# Altium schematic sheet Display_7Seg.SchDoc(Display_7Seg)
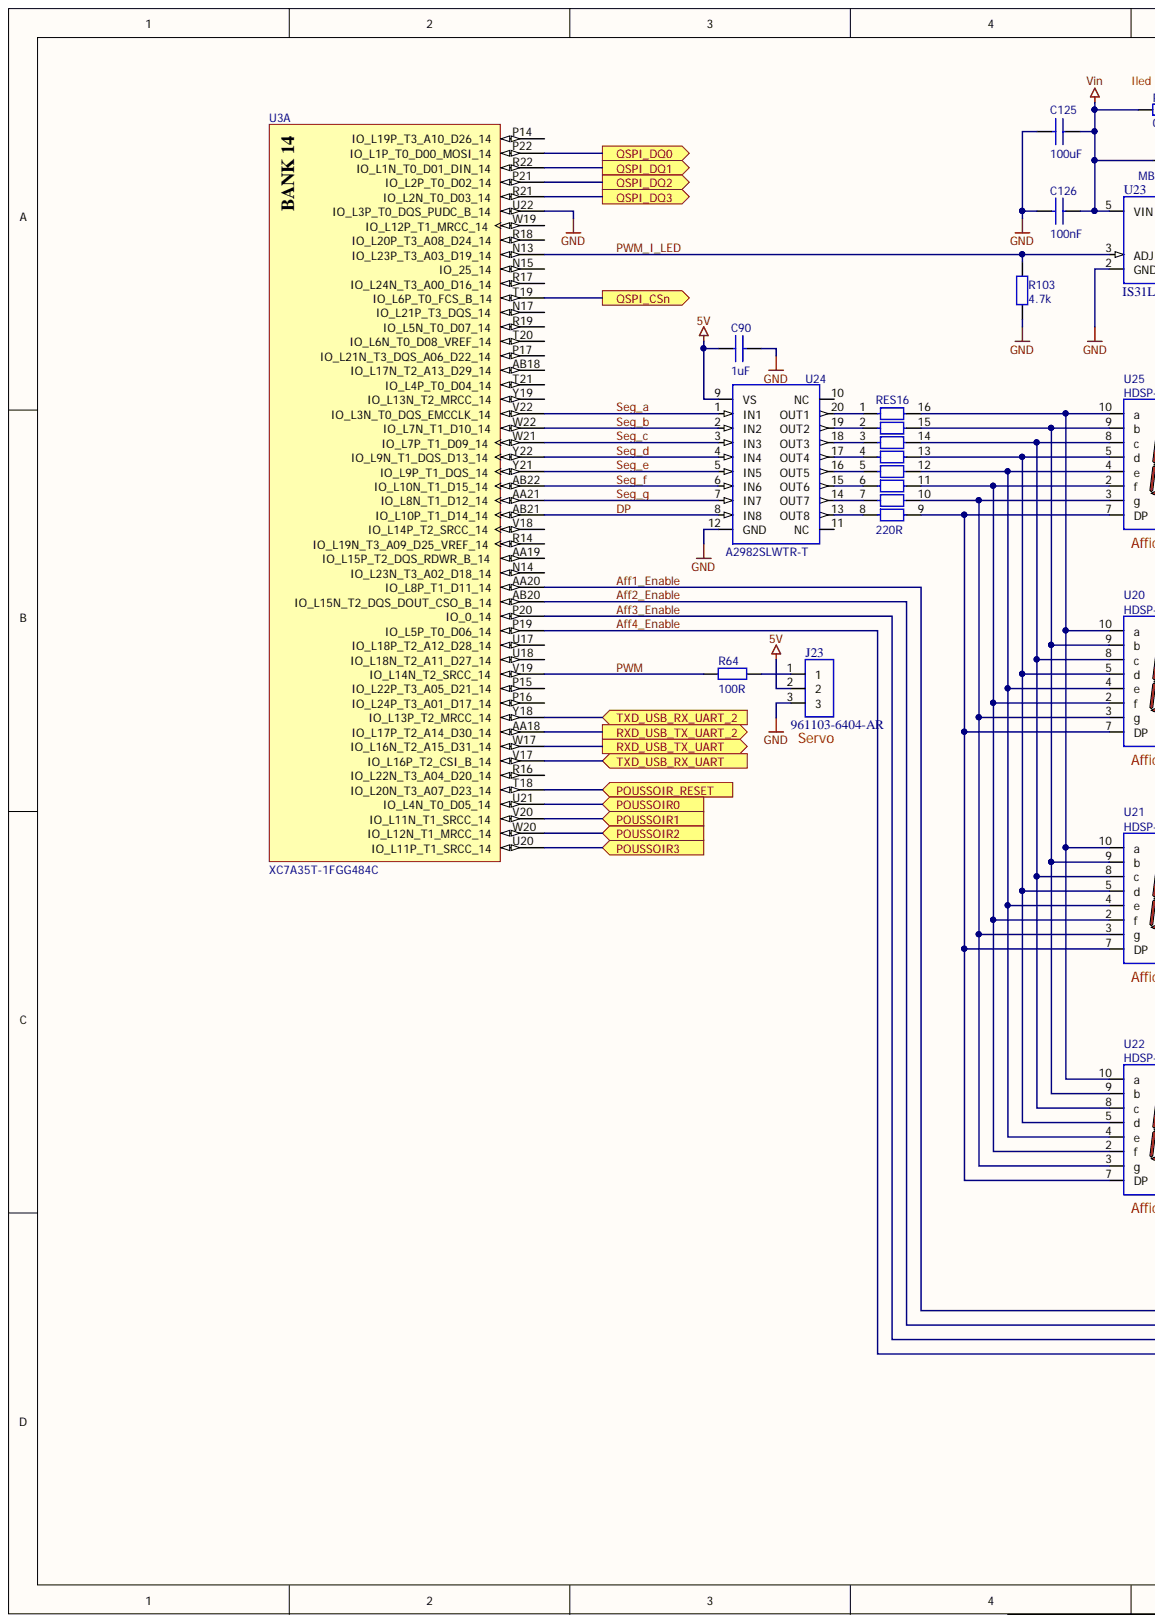
[diagram p0: Display_7Seg.SchDoc, left]
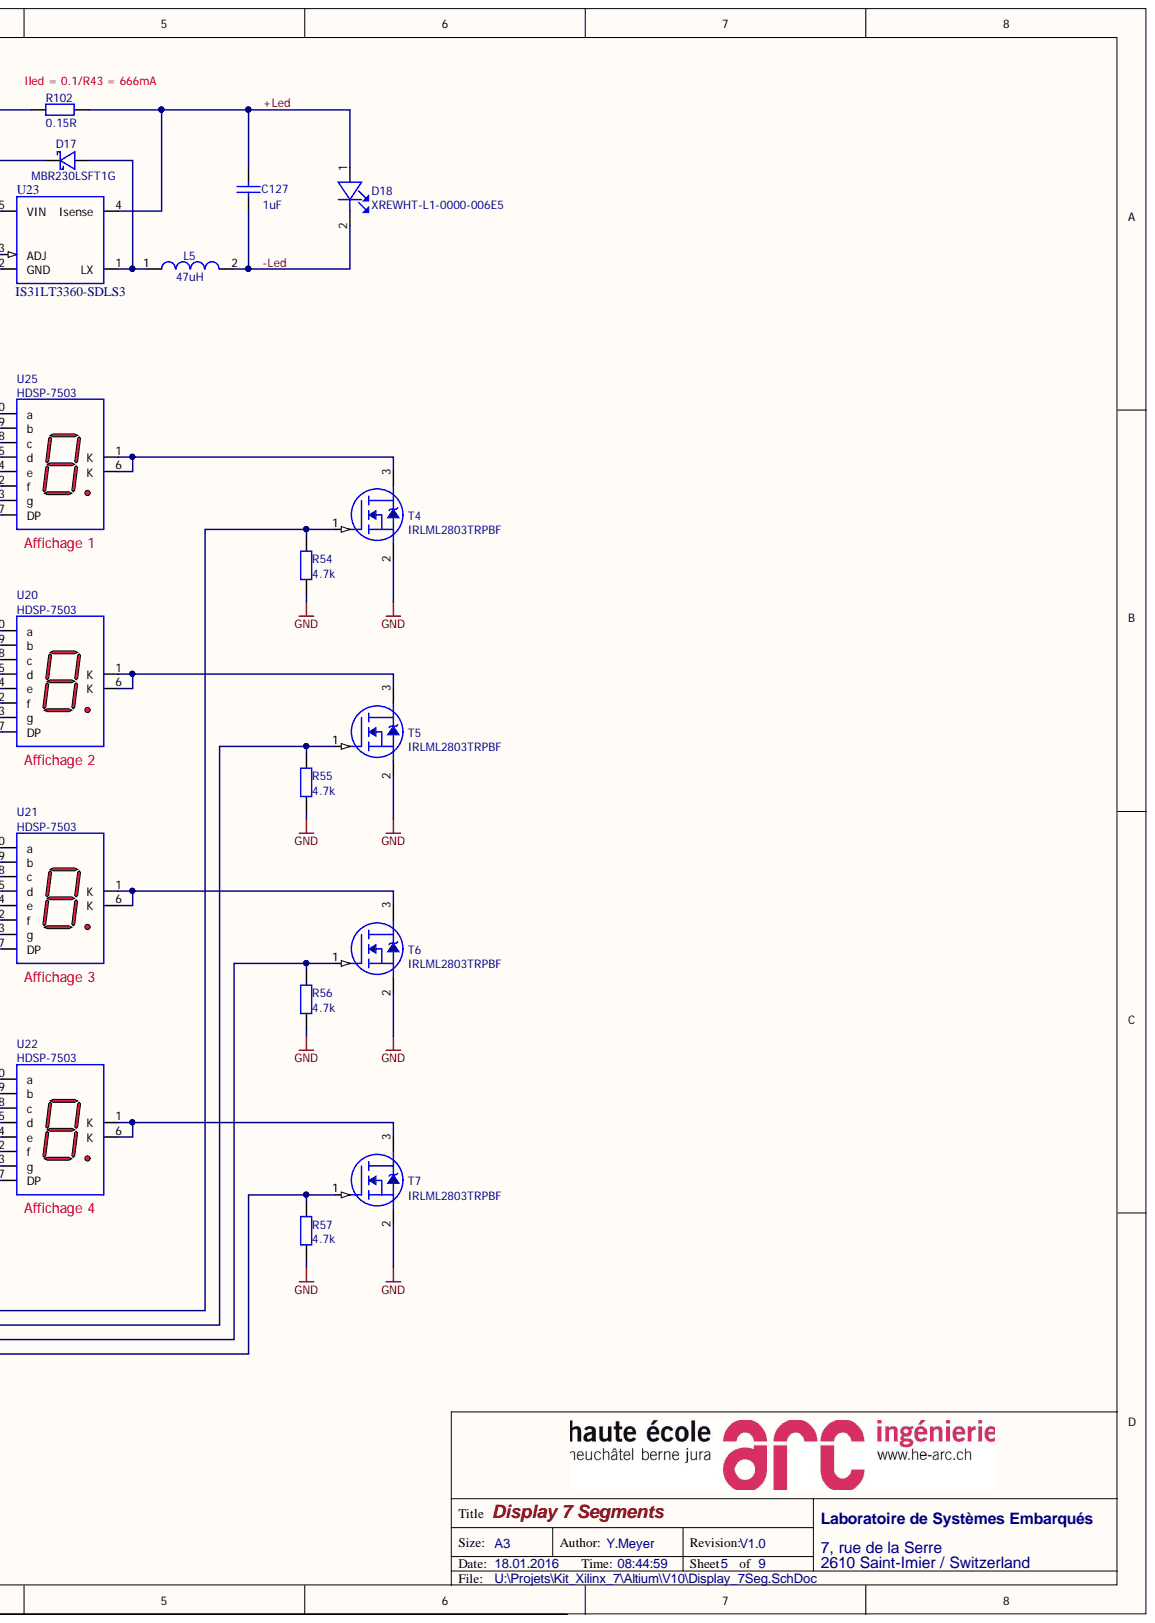
[diagram p1: Display_7Seg.SchDoc, right]

## Parts
C90  value 1uF  [060301]  (hidden comment: C0603C105K4RACTU)
C125  value 100uF  [121001]  (hidden comment: GRM32ER60J107ME20L)
C126  value 100nF  [060301]  (hidden comment: C0603C104Z3VAC7867)
C127  value 1uF  [060301]  (hidden comment: C0603C105K4RACTU)
D17  MBR230LSFT1G  [SOD123LF]
D18  XREWHT-L1-0000-006E5  [D008]
J23  961103-6404-AR  [J103A01]
L5  value 47uH  [SRN6045]  (hidden comment: SRN6045-470M)
R54  value 4.7k  [060301]  (hidden comment: ERJ-3EKF4701V)
R55  value 4.7k  [060301]  (hidden comment: ERJ-3EKF4701V)
R56  value 4.7k  [060301]  (hidden comment: ERJ-3EKF4701V)
R57  value 4.7k  [060301]  (hidden comment: ERJ-3EKF4701V)
R64  value 100R  [060301]  (hidden comment: RC0603FR-07100RL)
R102  value 0.15R  [120601]  (hidden comment: RL1206FR-7W0R15L)
R103  value 4.7k  [060301]  (hidden comment: ERJ-3EKF4701V)
RES16  value 220R  [SOP1601]  (hidden comment: 767163221GP)
T4  IRLML2803TRPBF  [SOT2301]
T5  IRLML2803TRPBF  [SOT2301]
T6  IRLML2803TRPBF  [SOT2301]
T7  IRLML2803TRPBF  [SOT2301]
U3  XC7A35T-1FGG484C  [FGG484]  (part A)
U20  HDSP-7503  [HDSP-7503]
U21  HDSP-7503  [HDSP-7503]
U22  HDSP-7503  [HDSP-7503]
U23  IS31LT3360-SDLS3  [SOT89-5]
U24  A2982SLWTR-T  [SOL2001]
U25  HDSP-7503  [HDSP-7503]

## Ports
POUSSOIR0, POUSSOIR1, POUSSOIR2, POUSSOIR3, POUSSOIR_RESET, QSPI_CSN, QSPI_DQ0, QSPI_DQ1, QSPI_DQ2, QSPI_DQ3, RXD_USB_TX_UART, RXD_USB_TX_UART_2, TXD_USB_RX_UART, TXD_USB_RX_UART_2

## Nets
5V: C90.1 J23.2 U24.9(VS)
GND: C90.2 C125.2 C126.2 J23.3 R54.1 R55.1 R56.1 R57.1 R103.2 T4.2 T5.2 T6.2 T7.2 U3.U22(IO_L3P_T0_DQS_PUDC_B_14) U23.2(GND) U24.12(GND)
QSPI_CSN: U3.T19(IO_L6P_T0_FCS_B_14) ; port QSPI_CSN
VIN: C125.1 C126.1 D17.1 R102.2 U23.5(VIN)
+LED: C127.1 D18.1 R102.1 U23.4(Isense)
-LED: C127.2 D18.2 L5.2
AFF1_ENABLE: R54.2 T4.1 U3.AA20(IO_L8P_T1_D11_14)
AFF2_ENABLE: R55.2 T5.1 U3.AB20(IO_L15N_T2_DQS_DOUT_CSO_B_14)
AFF3_ENABLE: R56.2 T6.1 U3.P20(IO_0_14)
AFF4_ENABLE: R57.2 T7.1 U3.P19(IO_L5P_T0_D06_14)
DP: U3.AB21(IO_L10P_T1_D14_14) U24.8(IN8)
POUSSOIR0: U3.U21(IO_L4N_T0_D05_14) ; port POUSSOIR0
POUSSOIR1: U3.V20(IO_L11N_T1_SRCC_14) ; port POUSSOIR1
POUSSOIR2: U3.W20(IO_L12N_T1_MRCC_14) ; port POUSSOIR2
POUSSOIR3: U3.U20(IO_L11P_T1_SRCC_14) ; port POUSSOIR3
POUSSOIR_RESET: U3.T18(IO_L20N_T3_A07_D23_14) ; port POUSSOIR_RESET
PWM: R64.2 U3.V19(IO_L14N_T2_SRCC_14)
PWM_I_LED: R103.1 U3.N13(IO_L23P_T3_A03_D19_14) U23.3(ADJ)
QSPI_DQ0: U3.P22(IO_L1P_T0_D00_MOSI_14) ; port QSPI_DQ0
QSPI_DQ1: U3.R22(IO_L1N_T0_D01_DIN_14) ; port QSPI_DQ1
QSPI_DQ2: U3.P21(IO_L2P_T0_D02_14) ; port QSPI_DQ2
QSPI_DQ3: U3.R21(IO_L2N_T0_D03_14) ; port QSPI_DQ3
RXD_USB_TX_UART: U3.W17(IO_L16N_T2_A15_D31_14) ; port RXD_USB_TX_UART
RXD_USB_TX_UART_2: U3.AA18(IO_L17P_T2_A14_D30_14) ; port RXD_USB_TX_UART_2
SEG_A: U3.V22(IO_L3N_T0_DQS_EMCCLK_14) U24.1(IN1)
SEG_B: U3.W22(IO_L7N_T1_D10_14) U24.2(IN2)
SEG_C: U3.W21(IO_L7P_T1_D09_14) U24.3(IN3)
SEG_D: U3.Y22(IO_L9N_T1_DQS_D13_14) U24.4(IN4)
SEG_E: U3.Y21(IO_L9P_T1_DQS_14) U24.5(IN5)
SEG_F: U3.AB22(IO_L10N_T1_D15_14) U24.6(IN6)
SEG_G: U3.AA21(IO_L8N_T1_D12_14) U24.7(IN7)
TXD_USB_RX_UART: U3.V17(IO_L16P_T2_CSI_B_14) ; port TXD_USB_RX_UART
TXD_USB_RX_UART_2: U3.Y18(IO_L13P_T2_MRCC_14) ; port TXD_USB_RX_UART_2
NetD17_2: D17.2 L5.1 U23.1(LX)
NetJ23_1: J23.1 R64.1
NetRES16_1: RES16.1 U24.20(OUT1)
NetRES16_2: RES16.2 U24.19(OUT2)
NetRES16_3: RES16.3 U24.18(OUT3)
NetRES16_4: RES16.4 U24.17(OUT4)
NetRES16_5: RES16.5 U24.16(OUT5)
NetRES16_6: RES16.6 U24.15(OUT6)
NetRES16_7: RES16.7 U24.14(OUT7)
NetRES16_8: RES16.8 U24.13(OUT8)
NetRES16_9: RES16.9 U20.7(DP) U21.7(DP) U22.7(DP) U25.7(DP)
NetRES16_10: RES16.10 U20.3(g) U21.3(g) U22.3(g) U25.3(g)
NetRES16_11: RES16.11 U20.2(f) U21.2(f) U22.2(f) U25.2(f)
NetRES16_12: RES16.12 U20.4(e) U21.4(e) U22.4(e) U25.4(e)
NetRES16_13: RES16.13 U20.5(d) U21.5(d) U22.5(d) U25.5(d)
NetRES16_14: RES16.14 U20.8(c) U21.8(c) U22.8(c) U25.8(c)
NetRES16_15: RES16.15 U20.9(b) U21.9(b) U22.9(b) U25.9(b)
NetRES16_16: RES16.16 U20.10(a) U21.10(a) U22.10(a) U25.10(a)
NetT4_3: T4.3 U25.1(K) U25.6(K)
NetT5_3: T5.3 U20.1(K) U20.6(K)
NetT6_3: T6.3 U21.1(K) U21.6(K)
NetT7_3: T7.3 U22.1(K) U22.6(K)

Not connected: U3 AA19(IO_L15P_T2_DQS_RDWR_B_14) AB18(IO_L17N_T2_A13_D29_14) N14(IO_L23N_T3_A02_D18_14) N15(IO_25_14) N17(IO_L21P_T3_DQS_14) P14(IO_L19P_T3_A10_D26_14) P15(IO_L22P_T3_A05_D21_14) P16(IO_L24P_T3_A01_D17_14) P17(IO_L21N_T3_DQS_A06_D22_14) R14(IO_L19N_T3_A09_D25_VREF_14) R16(IO_L22N_T3_A04_D20_14) R17(IO_L24N_T3_A00_D16_14) R18(IO_L20P_T3_A08_D24_14) R19(IO_L5N_T0_D07_14) T20(IO_L6N_T0_D08_VREF_14) T21(IO_L4P_T0_D04_14) U17(IO_L18P_T2_A12_D28_14) U18(IO_L18N_T2_A11_D27_14) V18(IO_L14P_T2_SRCC_14) W19(IO_L12P_T1_MRCC_14) Y19(IO_L13N_T2_MRCC_14); U24 10(NC) 11(NC)

## Library data of the parts
C90 = CAPA: CAP CER 1UF 16V 10% X7R 0603; Component Kind: Standard; Supplier 1: Digi-Key; Supplier Part Number 1: 399-7847-6-ND; Library Name: EISI.SchLib
C125 = CAPA: CAP CER 100UF 6.3V X5R 1210; Component Kind: Standard; Supplier 1: Digi-Key; Supplier Part Number 1: 490-3390-1-ND; Library Name: EISI.SchLib
C126 = CAPA: CAP .10UF 25V CERAMIC Y5V 0603; Component Kind: Standard; Supplier 1: Digi-Key; Supplier Part Number 1: 399-1100-2-ND; Library Name: EISI.SchLib
C127 = Cap Semi: CAP CER 1UF 16V 10% X7R 0603; Component Kind: Standard; Library Name: Miscellaneous Devices.IntLib; Signal Integrity: Cap Semi; Simulation: CAP; Supplier 1: Digi-Key; Supplier Part Number 1: 399-7847-6-ND
D17 = MBR230LSFT1G: DIODE SCHOTTKY 30V 2A SOD123L; Component Kind: Standard; Library Name: PowerBoard.SchLib; Supplier 1: Digi-Key; Supplier Part Number 1: MBR230LSFT1GOSCT-ND
D18 = LED: LED XLAMP NEUTRAL WHT 4000K 2SMD; Component Kind: Standard; Supplier 1: Digi-Key; Supplier Part Number 1: XREWHT-L1-0000-006E5CT-ND; Library Name: EISI.SchLib
J23 = CON3: CONN HEADER VERT SGL 3POS GOLD; Component Kind: Standard; Supplier 1: Digi-Key; Supplier Part Number 1: 3M9448-ND; Library Name: EISI.SchLib
L5 = INDUCTOR: INDUCTOR POWER SHIELD 47UH SMD; Component Kind: Standard; Supplier 1: Digi-Key; Supplier Part Number 1: SRN6045-470MCT-ND; Library Name: EISI.SchLib
R54-R57 R103 = RES: RES 4.70K OHM 1/10W 1% 0603 SMD; Component Kind: Standard; Supplier 1: Digi-Key; Supplier Part Number 1: P4.70KHCT-ND; Library Name: EISI.SchLib
  R103: Revision: July-2002: Re-released for DXP Platform.
R64 = RES: RES SMD 100 OHM 1% 1/10W 0603; Component Kind: Standard; Supplier 1: Digi-Key; Supplier Part Number 1: 311-100HRCT-ND; Library Name: EISI.SchLib
R102 = RES: RES 0.15 OHM 1/2W 1% 1206 SMD; Component Kind: Standard; Supplier 1: Digi-Key; Supplier Part Number 1: 311-.15LYCT-ND; Library Name: EISI.SchLib
RES16 = RESEAU_8R: RES NET 8RES 220 OHM 16PIN SMD; Component Kind: Standard; Supplier 1: Digi-Key; Supplier Part Number 1: 767-163-R220P-ND; Library Name: EISI.SchLib
T4-T7 = IRLML2803: MOSFET N-CH 30V 1.2A SOT-23; Component Kind: Standard; Supplier 1: Digi-Key; Supplier Part Number 1: IRLML2803PBFTR-ND; Library Name: EISI.SchLib
U3 = XC7A35T-1FGG484C: Artix-7 FPGA, 250 User I/Os, 4 GTP, 484-Ball BGA, Speed Grade 1, Commercial Grade, Pb-Free; Manufacturer 1: Xilinx; Component Kind: Standard; Library Name: KitXilinx7.SCHLIB; Manufacturer Part Number 1: XC7A35T-1FGG484C; Supplier 1: Avnet Express; Supplier Part Number 1: XC7A35T-1FGG484C; Supplier Subtotal 1: 2140; Supplier Unit Price 1: 52.1952
U20-U22 U25 = HDSP-7503: LED 7-SEG 7.6MM CC HE RED RHD; Component Kind: Standard; Supplier 1: Digi-Key; Supplier Part Number 1: 516-1203-5-ND; Library Name: EISI.SchLib
U23 = IS31LT3360: IC LED DRVR STEP DOWN 5SOT89; Component Kind: Standard; Supplier 1: Digi-Key; Supplier Part Number 1: 706-1159-1-ND; Library Name: EISI.SchLib
U24 = A2982SLW: IC SOURCE DRIVER 8CHAN 20-SOIC; Component Kind: Standard; Supplier 1: Digi-Key; Supplier Part Number 1: 620-1127-1-ND; Library Name: EISI.SchLib
